# Altium schematic sheet PS_and_connectors.SchDoc(PS_and_connectors)
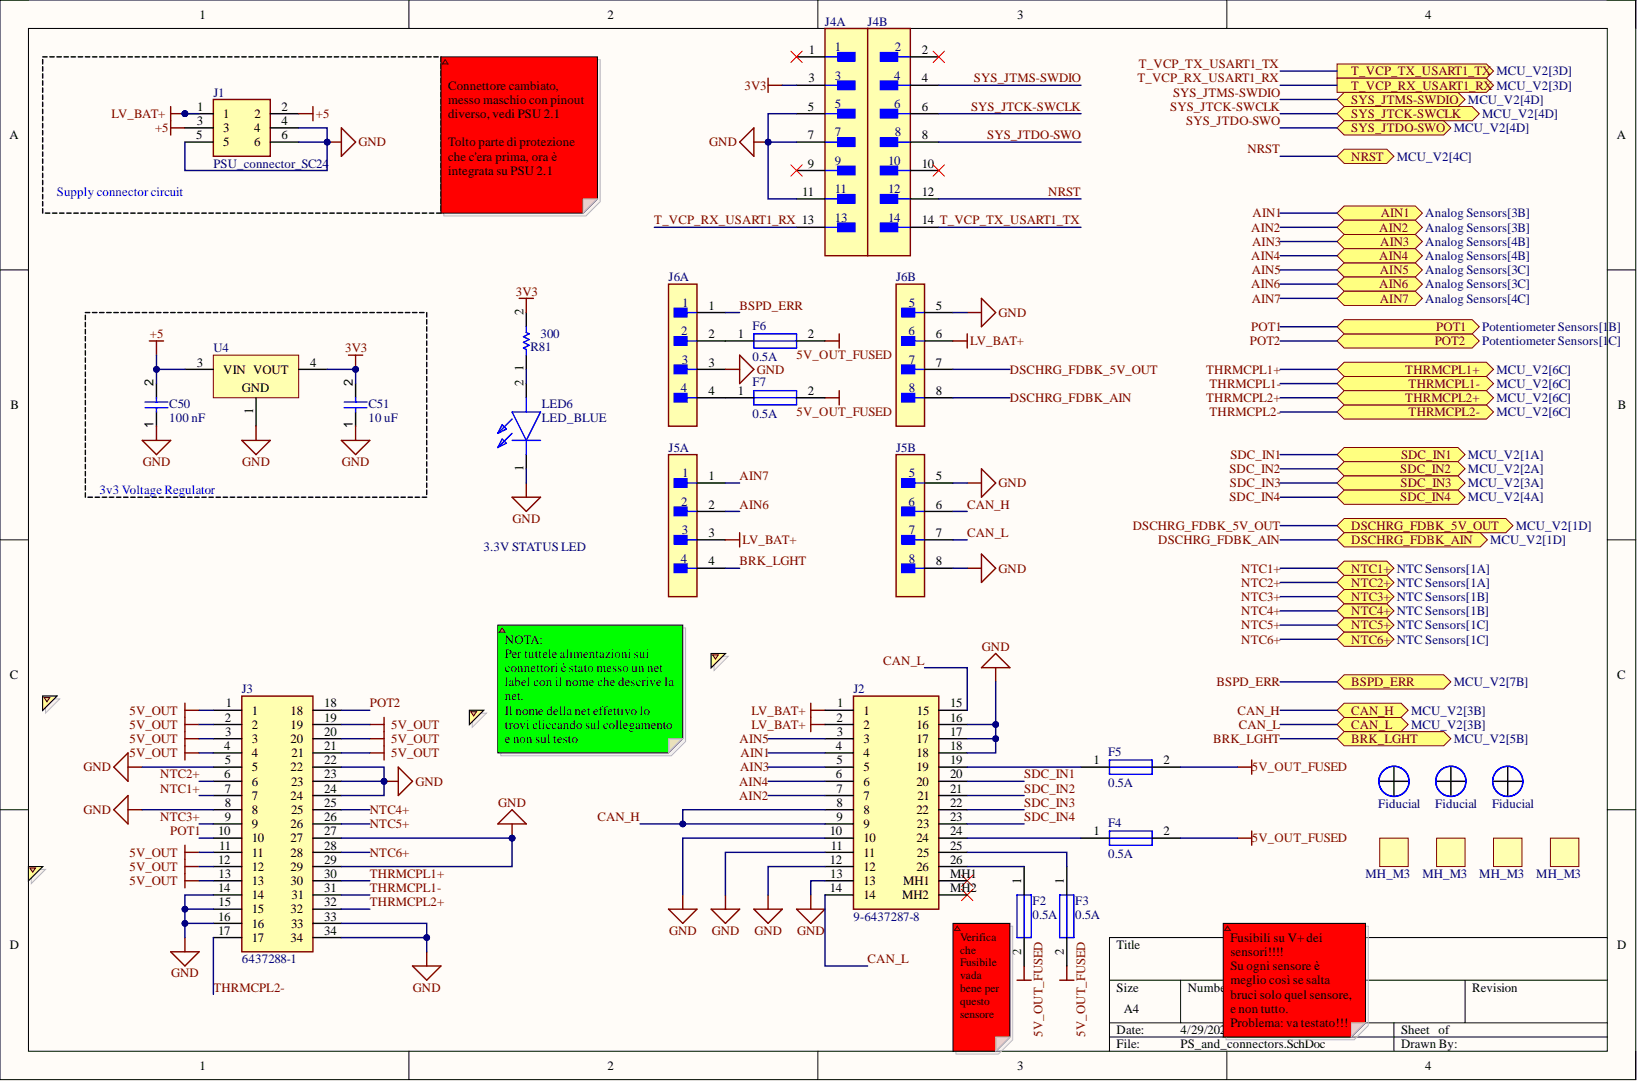
[diagram p0: PS_and_connectors.SchDoc]

## Parts
C50  100 nF
C51  10 uF
F2  0.5A
F3  0.5A
F4  0.5A
F5  0.5A
F6  0.5A
F7  0.5A
J1  PSU_connector_SC24
J2  9-6437287-8
J3  6437288-1
J4  FTSH-107-01-L-DV-K-A  (value not drawn)  (parts A,B)
J5  105314-1208  (value not drawn)  (parts A,B)
J6  105314-1208  (value not drawn)  (parts A,B)
LED6  LED_BLUE
R81  300
U4  Voltage Regulator 3.3V  (value not drawn)
  Fiducial
  Fiducial
  Fiducial
  MH_M3
  MH_M3
  MH_M3
  MH_M3

## Ports
AIN1, AIN2, AIN3, AIN4, AIN5, AIN6, AIN7, BRK_LGHT, BSPD_ERR, CAN_H, CAN_L, DSCHRG_FDBK_5V_OUT, DSCHRG_FDBK_AIN, NRST, NTC1+, NTC2+, NTC3+, NTC4+, NTC5+, NTC6+, POT1, POT2, SDC_IN1, SDC_IN2, SDC_IN3, SDC_IN4, SYS_JTCK-SWCLK, SYS_JTDO-SWO, SYS_JTMS-SWDIO, T_VCP_RX_USART1_RX, T_VCP_TX_USART1_TX, THRMCPL1+, THRMCPL1-, THRMCPL2+, THRMCPL2-

## Nets
3V3: C51.2 J4.3 R81.2 U4.4
GND: C50.1 C51.1 J1.4 J1.5 J1.6 J2.10 J2.11 J2.12 J2.13 J2.16 J2.17 J2.18 J3.5 J3.8 J3.14 J3.15 J3.16 J3.22 J3.23 J3.24 J3.27 J3.29 J3.33 J3.34 J4.5 J4.7 J4.11 J5.5 J5.8 J6.3 J6.5 LED6.1 U4.1
LV_BAT+: J1.1 J2.1 J2.2 J5.3 J6.6
5V_OUT: C50.2 F2.2 F3.2 F4.2 F5.2 F6.2 F7.2 J1.2 J1.3 J3.1 J3.2 J3.3 J3.4 J3.11 J3.12 J3.13 J3.19 J3.20 J3.21 U4.3
AIN1: J2.4 ; port AIN1
AIN2: J2.7 ; port AIN2
AIN3: J2.5 ; port AIN3
AIN4: J2.6 ; port AIN4
AIN5: J2.3 ; port AIN5
AIN6: J5.2 ; port AIN6
AIN7: J5.1 ; port AIN7
BRK_LGHT: J5.4 ; port BRK_LGHT
BSPD_ERR: J6.1 ; port BSPD_ERR
CAN_H: J2.8 J2.9 J5.6 ; port CAN_H
CAN_L: J2.14 J2.15 J5.7 ; port CAN_L
DSCHRG_FDBK_5V_OUT: J6.7 ; port DSCHRG_FDBK_5V_OUT
DSCHRG_FDBK_AIN: J6.8 ; port DSCHRG_FDBK_AIN
NRST: J4.12 ; port NRST
NTC1+: J3.7 ; port NTC1+
NTC2+: J3.6 ; port NTC2+
NTC3+: J3.9 ; port NTC3+
NTC4+: J3.25 ; port NTC4+
NTC5+: J3.26 ; port NTC5+
NTC6+: J3.28 ; port NTC6+
POT1: J3.10 ; port POT1
POT2: J3.18 ; port POT2
SDC_IN1: J2.20 ; port SDC_IN1
SDC_IN2: J2.21 ; port SDC_IN2
SDC_IN3: J2.22 ; port SDC_IN3
SDC_IN4: J2.23 ; port SDC_IN4
SYS_JTCK-SWCLK: J4.6 ; port SYS_JTCK-SWCLK
SYS_JTDO-SWO: J4.8 ; port SYS_JTDO-SWO
SYS_JTMS-SWDIO: J4.4 ; port SYS_JTMS-SWDIO
T_VCP_RX_USART1_RX: J4.13 ; port T_VCP_RX_USART1_RX
T_VCP_TX_USART1_TX: J4.14 ; port T_VCP_TX_USART1_TX
THRMCPL1+: J3.30 ; port THRMCPL1+
THRMCPL1-: J3.31 ; port THRMCPL1-
THRMCPL2+: J3.32 ; port THRMCPL2+
THRMCPL2-: J3.17 ; port THRMCPL2-
NetF2_1: F2.1 J2.26
NetF3_1: F3.1 J2.25
NetF4_1: F4.1 J2.24
NetF5_1: F5.1 J2.19
NetF6_1: F6.1 J6.2
NetF7_1: F7.1 J6.4
NetLED6_2: LED6.2 R81.1

Not connected: J2 MH1 MH2; J4 1 2 9 10

## Library data of the parts
C50 = CMP-00009-1: VJ0805Y104KBABE31; Component Kind: Standard; Library Name: EMEA- Squadra Corse PoliTO-  Politecnico di Torino
C51 = CMP-00009-1: CL21A106KOQNNNG; Component Kind: Standard; Library Name: EMEA- Squadra Corse PoliTO-  Politecnico di Torino
F2-F7 = CMP-005-00017-1: 0466.500NR; Tech: SMD; Component Kind: Standard; ComponentLink1Description: Website; Package: 1206; Library Name: EMEA- Squadra Corse PoliTO-  Politecnico di Torino
J1 = CMP-00019-2: 79107-7002; Component Kind: Standard; Library Name: EMEA- Squadra Corse PoliTO-  Politecnico di Torino
J2 = CMP-003-000028-1: Connector; Manufacturer_Name: TE Connectivity; Arrow Part Number: 9-6437287-8; Arrow Price/Stock: https://www.arrow.com/en/products/9-6437287-8/te-connectivity?region=nac; Component Kind: Standard; Datasheet Link: https://componentsearchengine.com/Datasheets/2/9-6437287-8.pdf; Design Item ID: 9-6437287-8; Height: 27.9mm; Manufacturer_Part_Number: 9-6437287-8; Mouser Part Number: 571-9-6437287-8; Mouser Price/Stock: https://www.mouser.co.uk/ProductDetail/TE-Connectivity/9-6437287-8?qs=c1ErmWplw0NzPHbjoONVkQ%3D%3D; Source: SamacSys.SchLib; Library Name: EMEA- Squadra Corse PoliTO-  Politecnico di Torino
J3 = CMP-011-000002-1: Super Seal Rcp,4row,34way pin contacts; Manufacturer_Name: TE Connectivity; Arrow Part Number: 6437288-1; Arrow Price/Stock: https://www.arrow.com/en/products/6437288-1/te-connectivity; Component Kind: Standard; Datasheet Link: http://www.te.com/commerce/DocumentDelivery/DDEController?Action=srchrtrv&DocNm=6437288-1&DocType=Customer+Drawing&DocLang=Japanese; Design Item ID: 6437288-1; Manufacturer_Part_Number: 6437288-1; Mouser Part Number: 571-6437288-1; Mouser Price/Stock: https://www.mouser.co.uk/ProductDetail/TE-Connectivity/6437288-1?qs=WBj8811fzwGYtKR8aBSJZw%3D%3D; Source: SamacSys.SchLib; Library Name: EMEA- Squadra Corse PoliTO-  Politecnico di Torino
J4 = CMP-02766-001414-1: CONN HEADER SMD 14POS 1.27MM; Gender: Male; Number of Contacts: 14; Component Kind: Standard; Contact Material: Bronze; Contact Plating: Gold; Lead Free: Lead Free; Library Name: Altium Content Vault; Number of Rows: 2; Pitch: 1.27mm; RoHS Compliant: Yes; Termination: Solder
J5 J6 = CMP-04776-000952-1: CONN HEADER R/A 8POS 2.5MM; Gender: Male; Color: Black; Component Kind: Standard; Contact Material: Brass; Contact Plating: Gold; Library Name: Altium Content Vault; Mount: Through Hole; Number of Rows: 2; Termination: Through Hole
LED6 = CMP-031-000016-1: APT2012LVBC_D; Manufacturer_Name: Kingbright; Manufacturer_Part_Number: APT2012LVBC/D; Tech: SMD; Arrow Part Number: APT2012LVBC/D; Arrow Price/Stock: https://www.arrow.com/en/products/apt2012lvbcd/kingbright; Component Kind: Standard; ComponentLink1Description: Website; ComponentLink1URL: https://www.mouser.it/ProductDetail/Kingbright/APT2012LVBC-D?qs=6oMev5NRZMGErJYT6KZLXg%3D%3D; Datasheet Link: https://componentsearchengine.com/Datasheets/1/APT2012LVBC_D.pdf; Design Item ID: APT2012LVBC_D; Height: 0.85mm; Mouser Part Number: 604-APT2012LVBCD; Mouser Price/Stock: https://www.mouser.co.uk/ProductDetail/Kingbright/APT2012LVBC-D?qs=6oMev5NRZMGErJYT6KZLXg%3D%3D; Package: 0805; Source: SamacSys.SchLib; Library Name: EMEA- Squadra Corse PoliTO-  Politecnico di Torino
R81 = CMP-009-00179-5: CRCW0603300RFKEA; Tech: SMD; Component Kind: Standard; ComponentLink1Description: Website; Package: 0603; Library Name: EMEA- Squadra Corse PoliTO-  Politecnico di Torino
U4 = CMP-0244-00089-1: LD1117DT33CTR; Tech: SMD; Component Kind: Standard; ComponentLink1Description: Manufacturer URL; ComponentLink1URL: http://www.st.com; ComponentLink2Description: Datasheet; ComponentLink2URL: http://www.st.com/internet/com/TECHNICAL_RESOURCES/TECHNICAL_LITERATURE/DATASHEET/CD00000544.pdf; ComponentLink3Description: Website; ComponentLink3URL: https://www.mouser.it/ProductDetail/STMicroelectronics/LD1117DT33CTR?qs=rUxiu3TV3%2FNm%2FOyb7vJzpg%3D%3D; DatasheetVersion: 1/11/2011; Library Name: Altium Content Vault; PackageDescription: 3-Pin Transistor Outline Package 2.28 mm Pitch, 9.7 mm Lead Span (DPAK); PackageReference: TO-252; PackageVersion: 2011
   = CMP-00028-00007-10: Component Kind: Standard; Library Name: EMEA- Squadra Corse PoliTO-  Politecnico di Torino
    = CMP-00028-00008-3: Mountinh Hole M3, 3,5 mm hole, 6,5 mm external; Component Kind: Standard; Library Name: EMEA- Squadra Corse PoliTO-  Politecnico di Torino
